# Altium schematic sheet gsm1.SchDoc(gsm1)
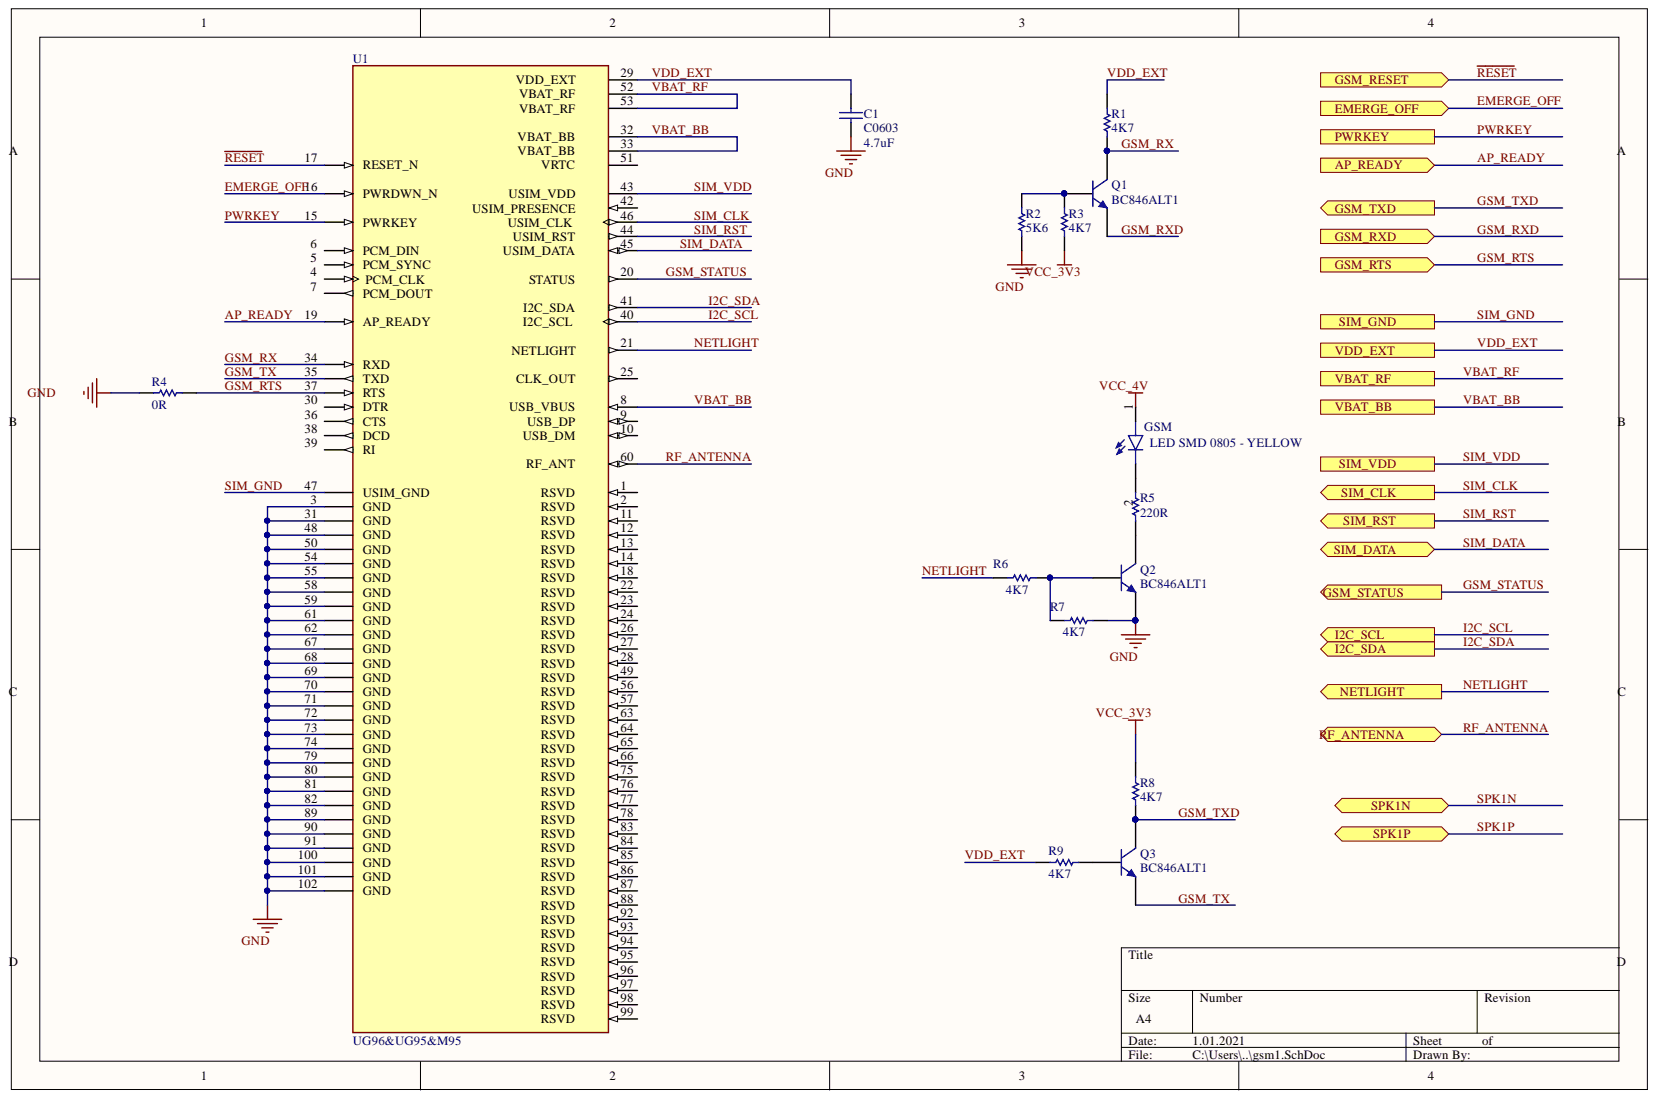
[diagram p0: gsm1.SchDoc]

## Parts
C1  C0603  value 4.7uF  [C0603]
GSM  LED SMD 0805 - YELLOW  [LED SMD 0805 - YELLOW]
Q1  BC846ALT1  [BC846]
Q2  BC846ALT1  [BC846]
Q3  BC846ALT1  [BC846]
R1  value 4K7  [CC1608-0603]  (hidden comment: Res0603)
R2  value 5K6  [CC1608-0603]  (hidden comment: Res0603)
R3  value 4K7  [CC1608-0603]  (hidden comment: Res0603)
R4  value 0R  [CC1608-0603]  (hidden comment: Res0603)
R5  value 220R  [CC1608-0603]  (hidden comment: Res0603)
R6  value 4K7  [CC1608-0603]  (hidden comment: Res0603)
R7  value 4K7  [CC1608-0603]  (hidden comment: Res0603)
R8  value 4K7  [CC1608-0603]  (hidden comment: Res0603)
R9  value 4K7  [CC1608-0603]  (hidden comment: Res0603)
U1  UG96&UG95&M95  [UG96&UG95&M95]

## Ports
AP_READY, EMERGE_OFF, GSM_RESET, GSM_RTS, GSM_RXD, GSM_STATUS, GSM_TXD, I2C_SCL, I2C_SDA, NETLIGHT, PWRKEY, RF_ANTENNA, SIM_CLK, SIM_DATA, SIM_GND, SIM_RST, SIM_VDD, SPK1N, SPK1P, VBAT_BB, VBAT_RF, VDD_EXT

## Nets
GND: C1.1 Q2.2 R2.1 R4.1 R7.2 U1.3(GND) U1.31(GND) U1.48(GND) U1.50(GND) U1.54(GND) U1.55(GND) U1.58(GND) U1.59(GND) U1.61(GND) U1.62(GND) U1.67(GND) U1.68(GND) U1.69(GND) U1.70(GND) U1.71(GND) U1.72(GND) U1.73(GND) U1.74(GND) U1.79(GND) U1.80(GND) U1.81(GND) U1.82(GND) U1.89(GND) U1.90(GND) U1.91(GND) U1.100(GND) U1.101(GND) U1.102(GND)
VCC_3V3: R3.1 R8.2
VCC_4V: GSM.1
AP_READY: U1.19(AP_READY) ; port AP_READY
EMERGE_OFF: U1.16(PWRDWN_N) ; port EMERGE_OFF
GSM_RTS: R4.2 U1.37(RTS) ; port GSM_RTS
GSM_RX: Q1.3 R1.1 U1.34(RXD)
GSM_RXD: Q1.2 ; port GSM_RXD
GSM_STATUS: U1.20 ; port GSM_STATUS
GSM_TX: Q3.2 U1.35(TXD)
GSM_TXD: Q3.3 R8.1 ; port GSM_TXD
I2C_SCL: U1.40 ; port I2C_SCL
I2C_SDA: U1.41 ; port I2C_SDA
NETLIGHT: R6.1 U1.21 ; port NETLIGHT
PWRKEY: U1.15(PWRKEY) ; port PWRKEY
~{RESET}: U1.17(RESET_N) ; port GSM_RESET
RF_ANTENNA: U1.60 ; port RF_ANTENNA
SIM_CLK: U1.46 ; port SIM_CLK
SIM_DATA: U1.45 ; port SIM_DATA
SIM_GND: U1.47(USIM_GND) ; port SIM_GND
SIM_RST: U1.44 ; port SIM_RST
SIM_VDD: U1.43 ; port SIM_VDD
VBAT_BB: U1.8 U1.32 U1.33 ; port VBAT_BB
VBAT_RF: U1.52 U1.53 ; port VBAT_RF
VDD_EXT: C1.2 R1.2 R9.2 U1.29 ; port VDD_EXT
NetGSM_2: GSM.2 R5.2
NetQ1_1: Q1.1 R2.2 R3.2
NetQ2_1: Q2.1 R6.2 R7.1
NetQ2_3: Q2.3 R5.1
NetQ3_1: Q3.1 R9.1
SPK1N: ; port SPK1N
SPK1P: ; port SPK1P

Not connected: U1 1 2 4(PCM_CLK) 5(PCM_SYNC) 6(PCM_DIN) 7(PCM_DOUT) 9 10 11 12 13 14 18 22 23 24 25 26 27 28 30(DTR) 36(CTS) 38(DCD) 39(RI) 42 49 51 56 57 63 64 65 66 75 76 77 78 83 84 85 86 87 88 92 93 94 95 96 97 98 99

## Library data of the parts
C1 = C0603: Ceramic Chip Capacitor - Standard; Note: Footprint corresponds to dimensions for bulk cassette packaging. This case size has tightened tolerances.; Code_IPC: 1608 [0603]; Code_JEITA: 0603; Component Kind: Standard; ComponentLink1Description: Manufacturer Link; ComponentLink1URL: http://www.kemet.com/; ComponentLink2Description: Datasheet; ComponentLink2URL: http://www.kemet.com/kemet/web/homepage/kechome.nsf/vapubfilesname/F3102TA.pdf/$file/F3102TA.pdf; DatasheetDocument: Mar-2001; LatestRevisionDate: 19-Mar-2004; LatestRevisionNote: Silkscreen size reduced.; PackageDocument: Mar-2001; PackageReference: 0603; Published: 17-Jul-2002; Library Name: haberlesme.SchLib; Publisher: Altium Limited
Q1-Q3 = BC846ALT1: General Purpose Transistor NPN Silicon; Component Kind: Standard; ComponentLink1Description: Manufacturer Link; ComponentLink1URL: http://www.onsemi.com/site/products/landing?taxonomyID=194; ComponentLink2Description: Datasheet; ComponentLink2URL: http://www.onsemi.com/pub/Collateral/BC846ALT1-D.PDF; DatasheetVersion: 1997; LatestRevisionDate: 17-Jul-2002; LatestRevisionNote: Re-released for DXP Platform.; Library Name: Motorola Discrete BJT.IntLib; PackageDescription: SOT-23; 3 Leads; Body 3.0 x 2.6 mm, inc. leads (LxW max); PackageReference: 318-08; PackageVersion: 1997; Published: 29-Sep-2000; Signal Integrity: BC846ALT1; Simulation: BC846ALT1; Publisher: Altium Limited
R1-R9 = Res0603: Resistor; Manufacturer: Generic Components; Class I: Passive; Class II: Resistor; Component Kind: Standard; Published: 8-Jun-2000; Revision: July-2002: Re-released for DXP Platform.; Signal Integrity: Res1; Simulation: RESISTOR; Library Name: Isbak.SchLib; Publisher: Altium Limited
GSM = LED SMD 0805 - YELLOW: Component Kind: Standard; Library Name: haberlesme.SchLib
U1 = UG96&UG95&M95: Component Kind: Standard; Library Name: haberlesme.SchLib
